# Altium schematic sheet MAIN.SchDoc(MAIN)
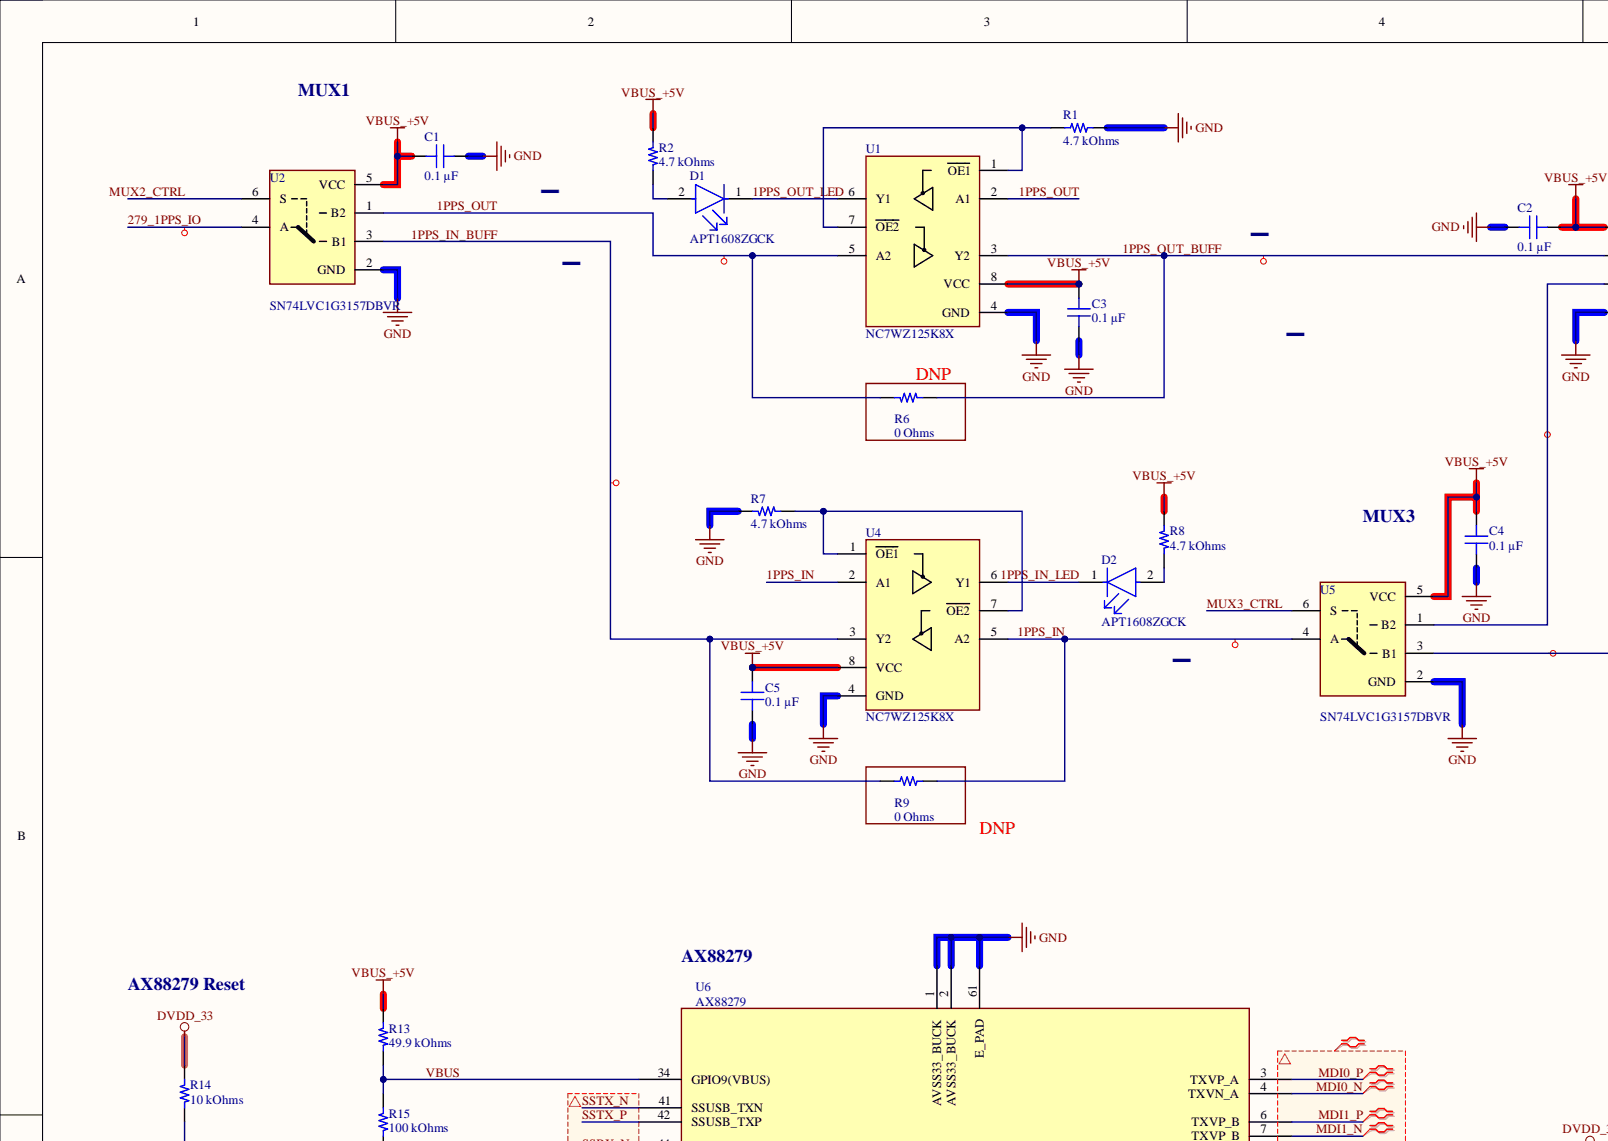
[diagram p0: MAIN.SchDoc, top left]
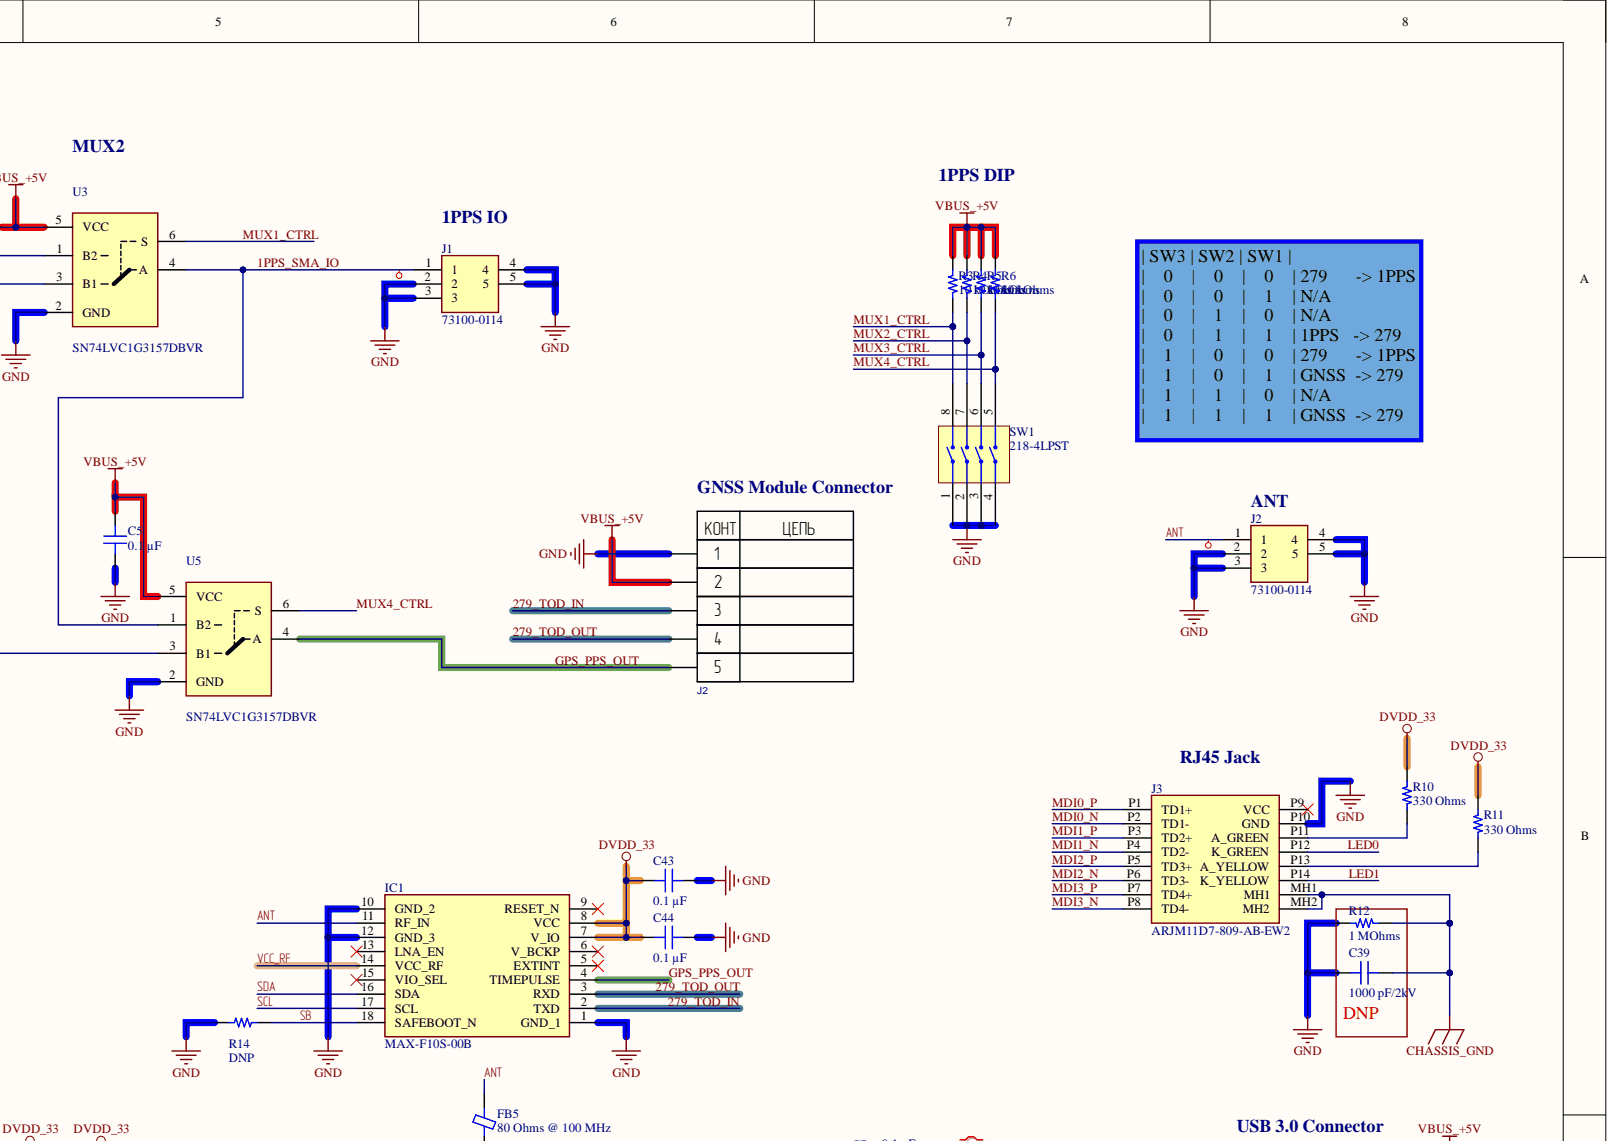
[diagram p1: MAIN.SchDoc, top right]
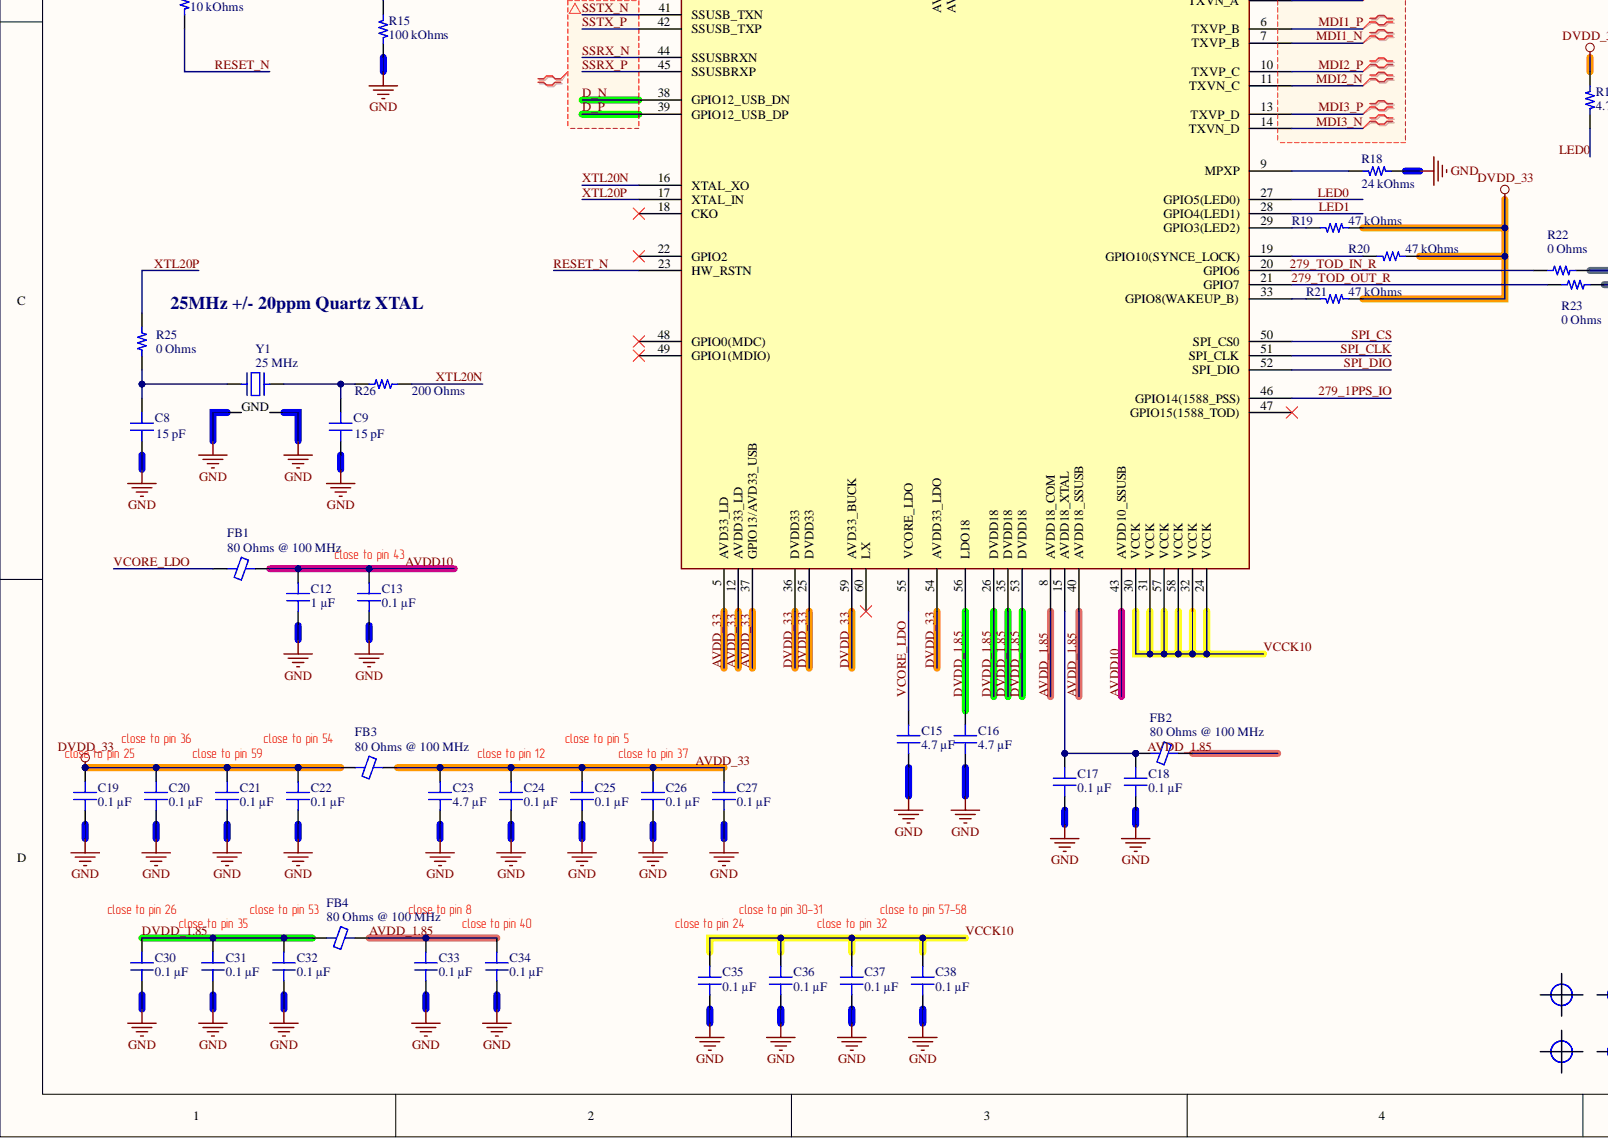
[diagram p2: MAIN.SchDoc, bottom left]
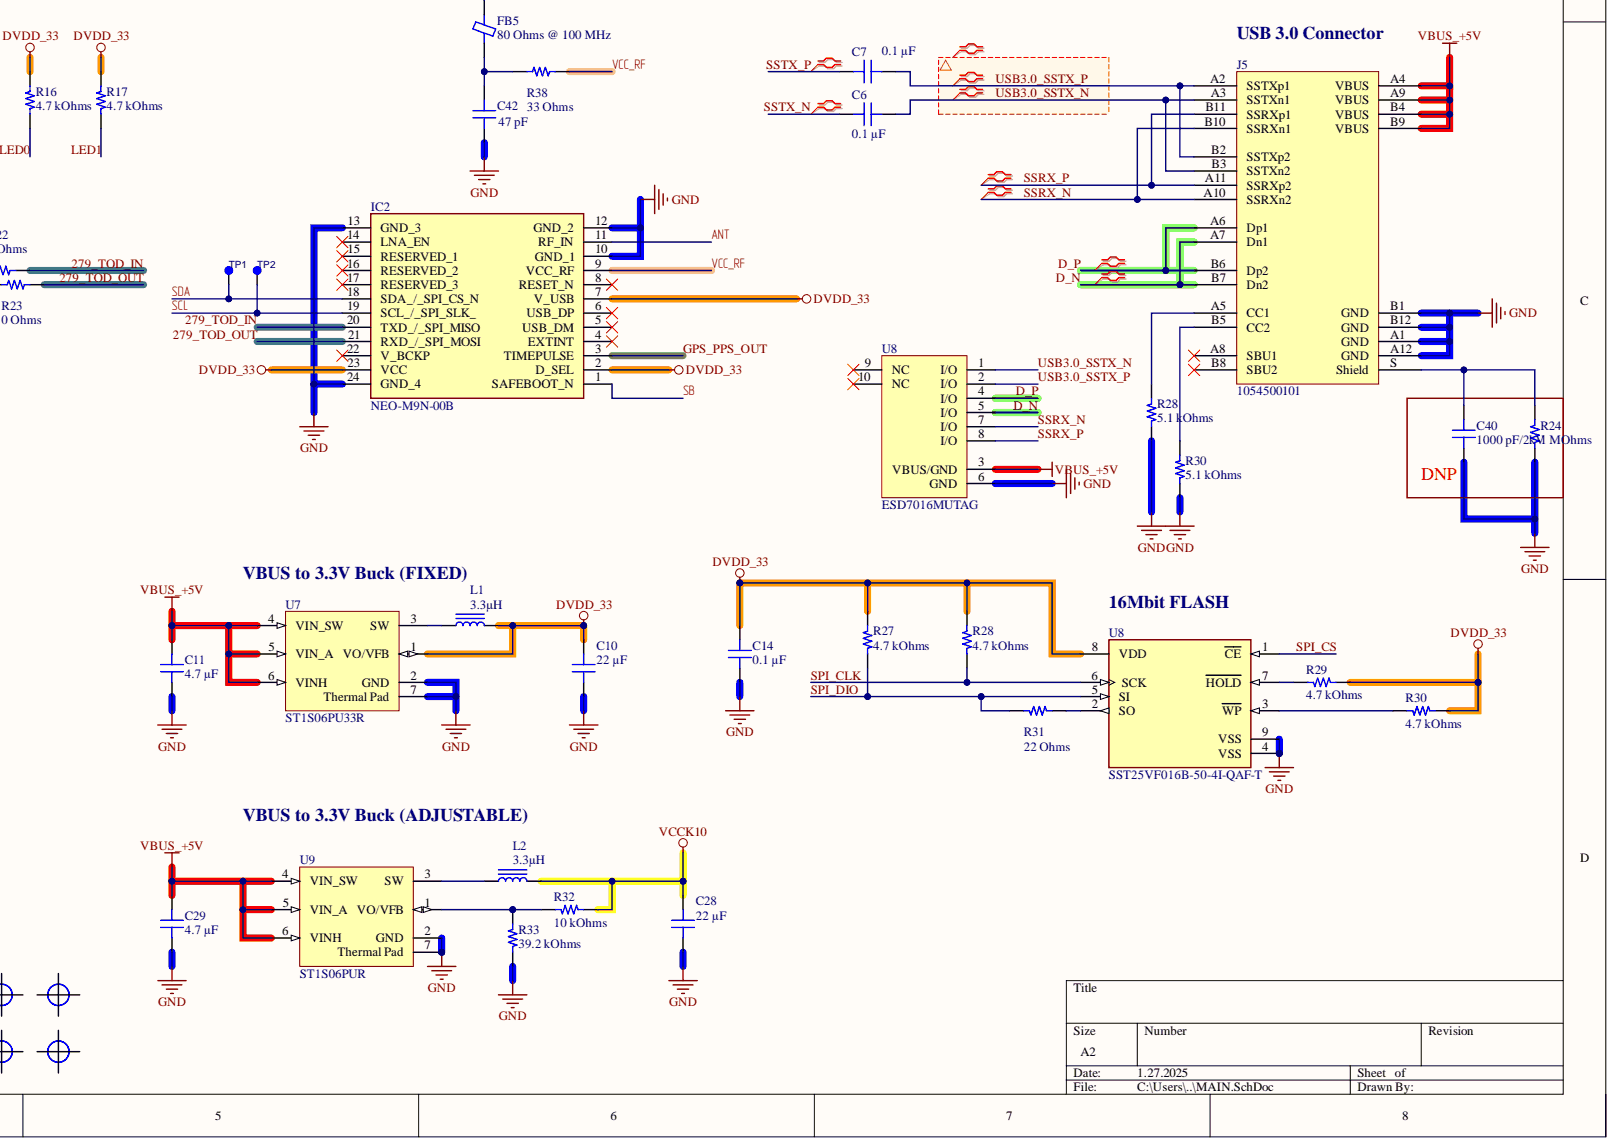
[diagram p3: MAIN.SchDoc, bottom right]

## Parts
C1  0.1 µF  [CAP 0402_1005]
C2  0.1 µF  [CAP 0402_1005]
C3  0.1 µF  [CAP 0402_1005]
C4  0.1 µF  [CAP 0402_1005]
C5  0.1 µF  [CAP 0402_1005]
C5  0.1 µF  [CAP 0402_1005]
C6  0.1 µF  [CAP 0402_1005]
C7  0.1 µF  [CAP 0402_1005]
C8  15 pF  [CAP 0402_1005]
C9  15 pF  [CAP 0402_1005]
C10  22 µF  [CAP 0603_1608]
C11  4.7 µF  [CAP 0402_1005]
C12  1 µF  [CAP 0402_1005]
C13  0.1 µF  [CAP 0402_1005]
C14  0.1 µF  [CAP 0402_1005]
C15  4.7 µF  [CAP 0402_1005]
C16  4.7 µF  [CAP 0402_1005]
C17  0.1 µF  [CAP 0402_1005]
C18  0.1 µF  [CAP 0402_1005]
C19  0.1 µF  [CAP 0402_1005]
C20  0.1 µF  [CAP 0402_1005]
C21  0.1 µF  [CAP 0402_1005]
C22  0.1 µF  [CAP 0402_1005]
C23  4.7 µF  [CAP 0402_1005]
C24  0.1 µF  [CAP 0402_1005]
C25  0.1 µF  [CAP 0402_1005]
C26  0.1 µF  [CAP 0402_1005]
C27  0.1 µF  [CAP 0402_1005]
C28  22 µF  [CAP 0603_1608]
C29  4.7 µF  [CAP 0402_1005]
C30  0.1 µF  [CAP 0402_1005]
C31  0.1 µF  [CAP 0402_1005]
C32  0.1 µF  [CAP 0402_1005]
C33  0.1 µF  [CAP 0402_1005]
C34  0.1 µF  [CAP 0402_1005]
C35  0.1 µF  [CAP 0402_1005]
C36  0.1 µF  [CAP 0402_1005]
C37  0.1 µF  [CAP 0402_1005]
C38  0.1 µF  [CAP 0402_1005]
C39  1000 pF/2kV  [CAP 1206_3216 - 0.8MM]
C40  1000 pF/2kV  [CAP 1206_3216 - 0.8MM]
C42  47 pF  [CAP 0402_1005]
C43  0.1 µF  [CAP 0402_1005]
C44  0.1 µF  [CAP 0402_1005]
D1  APT1608ZGCK  [FP-APT1608ZGCK-MFG]
D2  APT1608ZGCK  [FP-APT1608ZGCK-MFG]
FB1  80 Ohms @ 100 MHz  [FER 0402_1005]
FB2  80 Ohms @ 100 MHz  [FER 0402_1005]
FB3  80 Ohms @ 100 MHz  [FER 0402_1005]
FB4  80 Ohms @ 100 MHz  [FER 0402_1005]
FB5  80 Ohms @ 100 MHz  [FER 0402_1005]
IC1  MAX-F10S-00B  [MAXF10S00B]
IC2  NEO-M9N-00B  [NEOM9N00B]
J1  73100-0114  [731000114]
J2  73100-0114  [731000114]
J2  PBS-5  [PBS-5]  (value not drawn)
J3  ARJM11D7-809-AB-EW2  [ARJM11D7809ABEW2]
J5  1054500101  [Molex 1054500101]
L1  3.3µH  [IND 1008_2520]
L2  3.3µH  [IND 1008_2520]
MNT1  MOUNT_HOLE  [MOUNT_3mm]  (value not drawn)  (no BOM)
MNT2  MOUNT_HOLE  [MOUNT_3mm]  (value not drawn)  (no BOM)
MNT3  MOUNT_HOLE  [MOUNT_3mm]  (value not drawn)  (no BOM)
MNT4  MOUNT_HOLE  [MOUNT_3mm]  (value not drawn)  (no BOM)
R1  4.7 kOhms  [YAGEO RES 0402_1005]
R2  4.7 kOhms  [YAGEO RES 0402_1005]
R3  10 kOhms  [YAGEO RES 0402_1005]
R4  10 kOhms  [YAGEO RES 0402_1005]
R5  10 kOhms  [YAGEO RES 0402_1005]
R6  10 kOhms  [YAGEO RES 0402_1005]
R6  0 Ohms  [YAGEO RES 0402_1005]
R7  4.7 kOhms  [YAGEO RES 0402_1005]
R8  4.7 kOhms  [YAGEO RES 0402_1005]
R9  0 Ohms  [YAGEO RES 0402_1005]
R10  330 Ohms  [YAGEO RES 0402_1005]
R11  330 Ohms  [YAGEO RES 0402_1005]
R12  1 MOhms  [YAGEO RES 0402_1005]
R13  49.9 kOhms  [YAGEO RES 0402_1005]
R14  DNP  [YAGEO RES 0402_1005]
R14  10 kOhms  [YAGEO RES 0402_1005]
R15  100 kOhms  [YAGEO RES 0402_1005]
R16  4.7 kOhms  [YAGEO RES 0402_1005]
R17  4.7 kOhms  [YAGEO RES 0402_1005]
R18  24 kOhms  [YAGEO RES 0402_1005]
R19  47 kOhms  [YAGEO RES 0402_1005]
R20  47 kOhms  [YAGEO RES 0402_1005]
R21  47 kOhms  [YAGEO RES 0402_1005]
R22  0 Ohms  [YAGEO RES 0402_1005]
R23  0 Ohms  [YAGEO RES 0402_1005]
R24  1 MOhms  [YAGEO RES 0402_1005]
R25  0 Ohms  [YAGEO RES 0402_1005]
R26  200 Ohms  [YAGEO RES 0402_1005]
R27  4.7 kOhms  [YAGEO RES 0402_1005]
R28  5.1 kOhms  [YAGEO RES 0402_1005]
R28  4.7 kOhms  [YAGEO RES 0402_1005]
R29  4.7 kOhms  [YAGEO RES 0402_1005]
R30  5.1 kOhms  [YAGEO RES 0402_1005]
R30  4.7 kOhms  [YAGEO RES 0402_1005]
R31  22 Ohms  [YAGEO RES 0402_1005]
R32  10 kOhms  [YAGEO RES 0402_1005]
R33  39.2 kOhms  [YAGEO RES 0402_1005]
R38  33 Ohms  [YAGEO RES 0402_1005]
SW1  218-4LPST  [CTS 218-4LPJ]
TP1  PAD_TEST  [PAD_TEST]  (value not drawn)  (no BOM)
TP2  PAD_TEST  [PAD_TEST]  (value not drawn)  (no BOM)
U1  NC7WZ125K8X  [SOP50P310X90-8N]
U2  SN74LVC1G3157DBVR  [SOT95P280X145-6N]
U3  SN74LVC1G3157DBVR  [SOT95P280X145-6N]
U4  NC7WZ125K8X  [SOP50P310X90-8N]
U5  SN74LVC1G3157DBVR  [SOT95P280X145-6N]
U5  SN74LVC1G3157DBVR  [SOT95P280X145-6N]
U6  AX88279  [IC_AX88279]
U7  ST1S06PU33R  Output Voltage: 3.3V  [DFN6D_L]
U8  ESD7016MUTAG  [ONSC-UDFN-8-517CB_V]
U8  SST25VF016B-50-4I-QAF-T  Memory Type: FLASH  [FP-C04-452-MFG]
U9  ST1S06PUR  [DFN6D_M]
Y1  25 MHz  [NDK NX3225GA]

## Nets
CHASSIS_GND: C39.1 J3.MH1 J3.MH2 R12.1
GND: C1.1 C2.1 C3.1 C4.1 C5.1 C8.1 C9.1 C10.1 C11.1 C12.1 C13.1 C14.1 C15.1 C16.1 C17.1 C18.1 C19.1 C20.1 C21.1 C22.1 C23.1 C24.1 C25.1 C26.1 C27.1 C28.1 C29.1 C30.1 C31.1 C32.1 C33.1 C34.1 C35.1 C36.1 C37.1 C38.1 C39.2 C40.1 C42.1 C43.1 C44.1 IC1.1(GND_1) IC1.10(GND_2) IC1.12(GND_3) IC2.10(GND_1) IC2.12(GND_2) IC2.13(GND_3) IC2.24(GND_4) J1.2 J1.3 J1.4 J1.5 J2.1 J2.2 J2.3 J2.4 J2.5 J3.P10(GND) J5.A1(GND) J5.A12(GND) J5.B1(GND) J5.B12(GND) R1.2 R7.1 R12.2 R14.2 R15.1 R18.1 R24.1 R28.2 R30.2 R33.2 SW1.1 SW1.2 SW1.3 SW1.4 U1.4(GND) U2.2(GND) U3.2(GND) U4.4(GND) U5.2 U6.1(AVSS33_BUCK) U6.2(AVSS33_BUCK) U6.61(E_PAD) U7.2(GND) U7.7(Thermal_Pad) U8.4 U8.6 U8.9 U9.2(GND) U9.7(Thermal_Pad) Y1.2 Y1.4(GND)
VBUS_+5V: C1.2 C2.2 C3.2 C4.2 C5.2 C11.2 C29.2 J2.2 J5.A4(VBUS) J5.A9(VBUS) J5.B4(VBUS) J5.B9(VBUS) R2.2 R3.2 R4.2 R5.2 R6.2 R8.2 R13.2 U1.8(VCC) U2.5(VCC) U3.5(VCC) U4.8(VCC) U5.5 U7.4(VIN_SW) U7.5(VIN_A) U7.6(VINH) U8.3 U9.4(VIN_SW) U9.5(VIN_A) U9.6(VINH)
1PPS_IN: R9.1 U4.2(A1) U4.5(A2) U5.4
1PPS_IN_BUFF: R9.2 U2.3(B1) U4.3(Y2)
1PPS_IN_LED: D2.1 U4.6(Y1)
1PPS_OUT: R6.2 U1.2(A1) U1.5(A2) U2.1(B2)
1PPS_OUT_BUFF: R6.1 U1.3(Y2) U3.1(B2)
1PPS_OUT_LED: D1.1 U1.6(Y1)
1PPS_SMA_IO: J1.1 U3.4(A) U5.1
279_1PPS_IO: U2.4(A) U6.46(GPIO14(1588_PSS))
279_TOD_IN: IC1.2(TXD) IC2.20(TXD_/_SPI_MISO) J2.3 R22.1
279_TOD_IN_R: R22.2 U6.20(GPIO6)
279_TOD_OUT: IC1.3(RXD) IC2.21(RXD_/_SPI_MOSI) J2.4 R23.1
279_TOD_OUT_R: R23.2 U6.21(GPIO7)
ANT: FB5.2 IC1.11(RF_IN) IC2.11(RF_IN) J2.1
AVDD10: C12.2 C13.2 FB1.2 U6.43(AVDD10_SSUSB)
AVDD_1.85: C33.2 C34.2 FB2.2 FB4.2 U6.8(AVDD18_COM) U6.40(AVDD18_SSUSB)
AVDD_33: C23.2 C24.2 C25.2 C26.2 C27.2 FB3.2 U6.5(AVD33_LD) U6.12(AVDD33_LD) U6.37(GPIO13/AVD33_USB)
D_N: J5.A7(Dn1) J5.B7(Dn2) U6.38(GPIO12_USB_DN) U8.5
D_P: J5.A6(Dp1) J5.B6(Dp2) U6.39(GPIO12_USB_DP) U8.4
DVDD_1.85: C16.2 C30.2 C31.2 C32.2 FB4.1 U6.26(DVDD18) U6.35(DVDD18) U6.53(DVDD18) U6.56(LDO18)
DVDD_33: C10.2 C14.2 C19.2 C20.2 C21.2 C22.2 C43.2 C44.2 FB3.1 IC1.7(V_IO) IC1.8(VCC) IC2.2(D_SEL) IC2.7(V_USB) IC2.23(VCC) L1.2 R10.2 R11.2 R14.2 R16.1 R17.1 R19.2 R20.2 R21.2 R27.1 R28.1 R29.1 R30.1 U6.25(DVDD33) U6.36(DVDD33) U6.54(AVDD33_LDO) U6.59(AVD33_BUCK) U7.1(VO/VFB) U8.8
GPS_PPS_OUT: IC1.4(TIMEPULSE) IC2.3(TIMEPULSE) J2.5 U5.4
LED0: J3.P12(K_GREEN) R16.2 U6.27(GPIO5(LED0))
LED1: J3.P14(K_YELLOW) R17.2 U6.28(GPIO4(LED1))
MDI0_N: J3.P2(TD1-) U6.4(TXVN_A)
MDI0_P: J3.P1(TD1+) U6.3(TXVP_A)
MDI1_N: J3.P4(TD2-) U6.7(TXVP_B)
MDI1_P: J3.P3(TD2+) U6.6(TXVP_B)
MDI2_N: J3.P6(TD3-) U6.11(TXVN_C)
MDI2_P: J3.P5(TD3+) U6.10(TXVP_C)
MDI3_N: J3.P8(TD4-) U6.14(TXVN_D)
MDI3_P: J3.P7(TD4+) U6.13(TXVP_D)
MUX1_CTRL: R3.1 SW1.8 U3.6(S)
MUX2_CTRL: R4.1 SW1.7 U2.6(S)
MUX3_CTRL: R5.1 SW1.6 U5.6
MUX4_CTRL: R6.1 SW1.5 U5.6
RESET_N: R14.1 U6.23(HW_RSTN)
SB: IC1.18(SAFEBOOT_N) IC2.1(SAFEBOOT_N) R14.1
SCL: IC1.17(SCL) IC2.19(SCL_/_SPI_SLK_) TP2.1
SDA: IC1.16(SDA) IC2.18(SDA_/_SPI_CS_N) TP1.1
SPI_CLK: R28.2 U6.51(SPI_CLK) U8.6
SPI_CS: U6.50(SPI_CS0) U8.1
SPI_DIO: R27.2 R31.2 U6.52(SPI_DIO) U8.5
SSRX_N: J5.A10(SSRXn2) J5.B10(SSRXn1) U6.44(SSUSBRXN) U8.7
SSRX_P: J5.A11(SSRXp2) J5.B11(SSRXp1) U6.45(SSUSBRXP) U8.8
SSTX_N: C6.2 U6.41(SSUSB_TXN)
SSTX_P: C7.2 U6.42(SSUSB_TXP)
USB3.0_SSTX_N: C6.1 J5.A3(SSTXn1) J5.B3(SSTXn2) U8.1
USB3.0_SSTX_P: C7.1 J5.A2(SSTXp1) J5.B2(SSTXp2) U8.2
VBUS: R13.1 R15.2 U6.34(GPIO9(VBUS))
VCC_RF: IC1.14(VCC_RF) IC2.9(VCC_RF) R38.1
VCCK10: C28.2 C35.2 C36.2 C37.2 C38.2 L2.2 R32.1 U6.24(VCCK) U6.30(VCCK) U6.31(VCCK) U6.32(VCCK) U6.57(VCCK) U6.58(VCCK)
VCORE_LDO: C15.2 FB1.1 U6.55(VCORE_LDO)
XTL20N: R26.2 U6.16(XTAL_XO)
XTL20P: R25.2 U6.17(XTAL_IN)
NetC8_2: C8.2 R25.1 Y1.1
NetC9_2: C9.2 R26.1 Y1.3
NetC17_2: C17.2 C18.2 FB2.1 U6.15(AVDD18_XTAL)
NetC40_2: C40.2 J5.S(Shield) R24.2
NetC42_2: C42.2 FB5.1 R38.2
NetD1_2: D1.2 R2.1
NetD2_2: D2.2 R8.1
NetJ3_P11: J3.P11(A_GREEN) R10.1
NetJ3_P13: J3.P13(A_YELLOW) R11.1
NetJ5_A5: J5.A5(CC1) R28.1
NetJ5_B5: J5.B5(CC2) R30.1
NetL1_1: L1.1 U7.3(SW)
NetL2_1: L2.1 U9.3(SW)
NetR1_1: R1.1 U1.1(OE1) U1.7(OE2)
NetR7_2: R7.2 U4.1(OE1) U4.7(OE2)
NetR18_2: R18.2 U6.9(MPXP)
NetR19_1: R19.1 U6.29(GPIO3(LED2))
NetR20_1: R20.1 U6.19(GPIO10(SYNCE_LOCK))
NetR21_1: R21.1 U6.33(GPIO8(WAKEUP_B))
NetR29_2: R29.2 U8.7
NetR30_2: R30.2 U8.3
NetR31_1: R31.1 U8.2
NetR32_2: R32.2 R33.1 U9.1(VO/VFB)
NetU3_3: U3.3(B1) U5.1

Not connected: IC1 5(EXTINT) 6(V_BCKP) 9(RESET_N) 13(LNA_EN) 15(VIO_SEL); IC2 4(EXTINT) 5(USB_DM) 6(USB_DP) 8(RESET_N) 14(LNA_EN) 15(RESERVED_1) 16(RESERVED_2) 17(RESERVED_3) 22(V_BCKP); J3 P9(VCC); J5 A8(SBU1) B8(SBU2); U5 3; U6 18(CKO) 22(GPIO2) 47(GPIO15(1588_TOD)) 48(GPIO0(MDC)) 49(GPIO1(MDIO)) 60(LX); U8 10(NC)
